# Altium schematic sheet ADC.SchDoc(ADC)
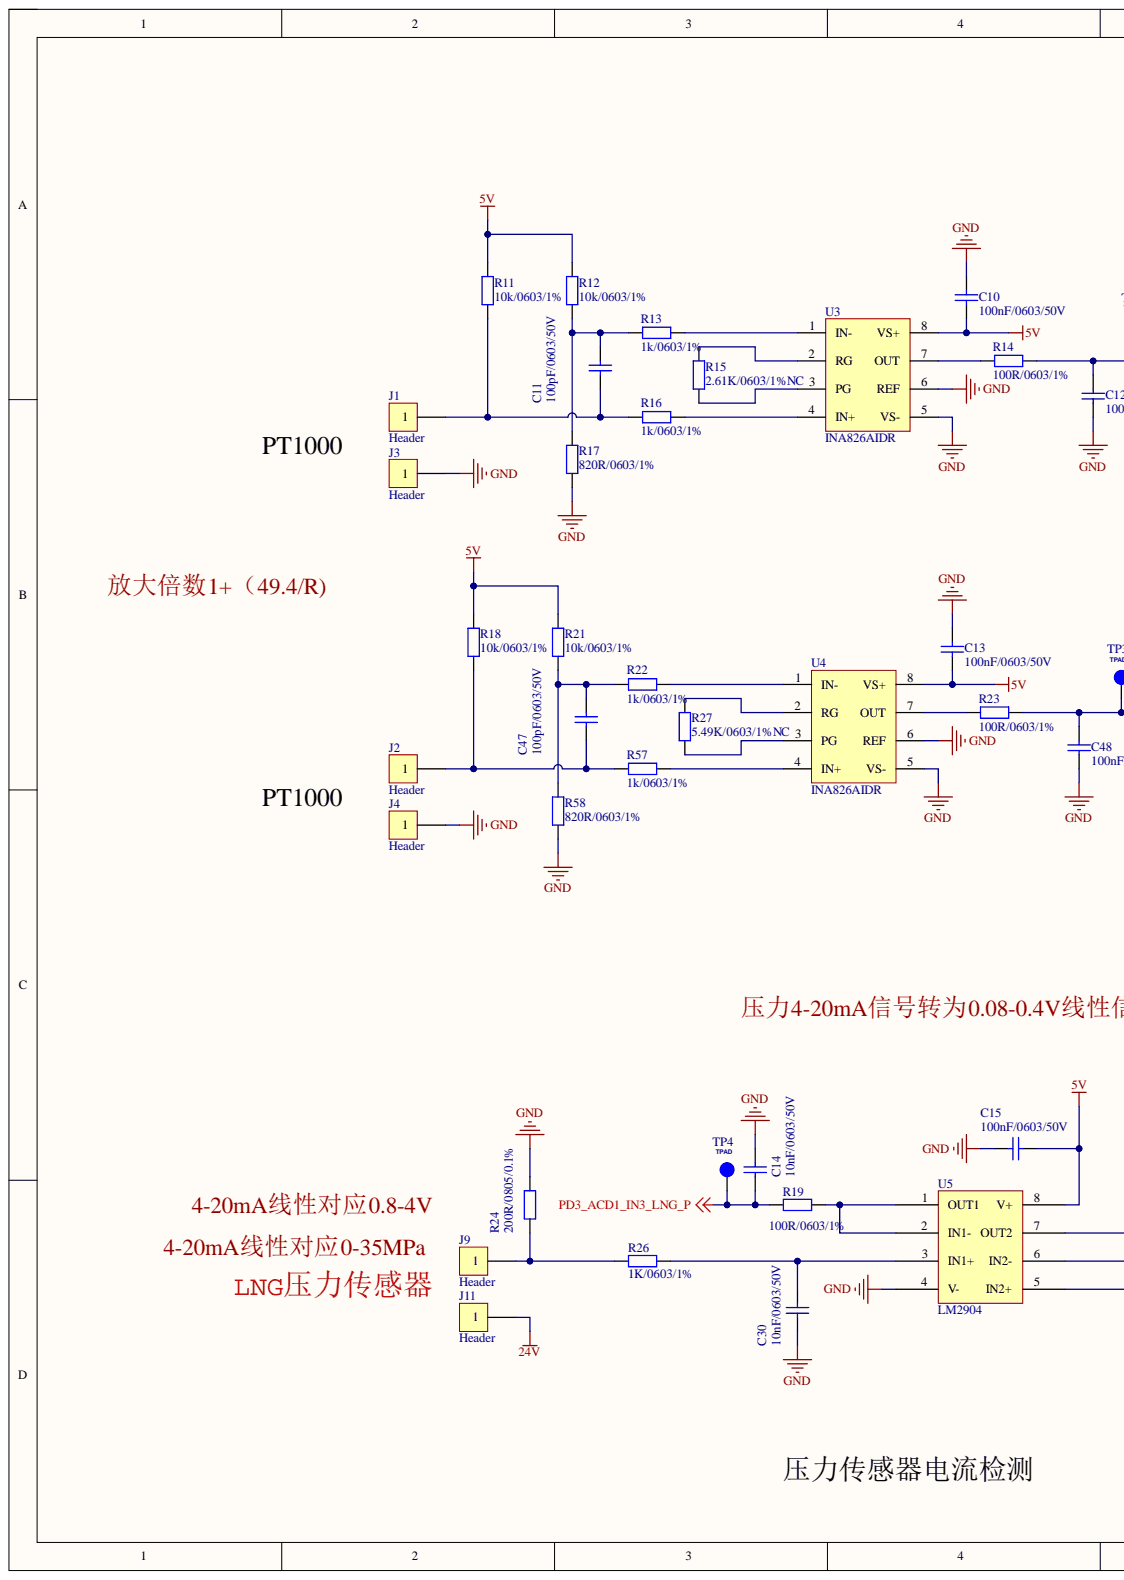
[diagram p0: ADC.SchDoc, left]
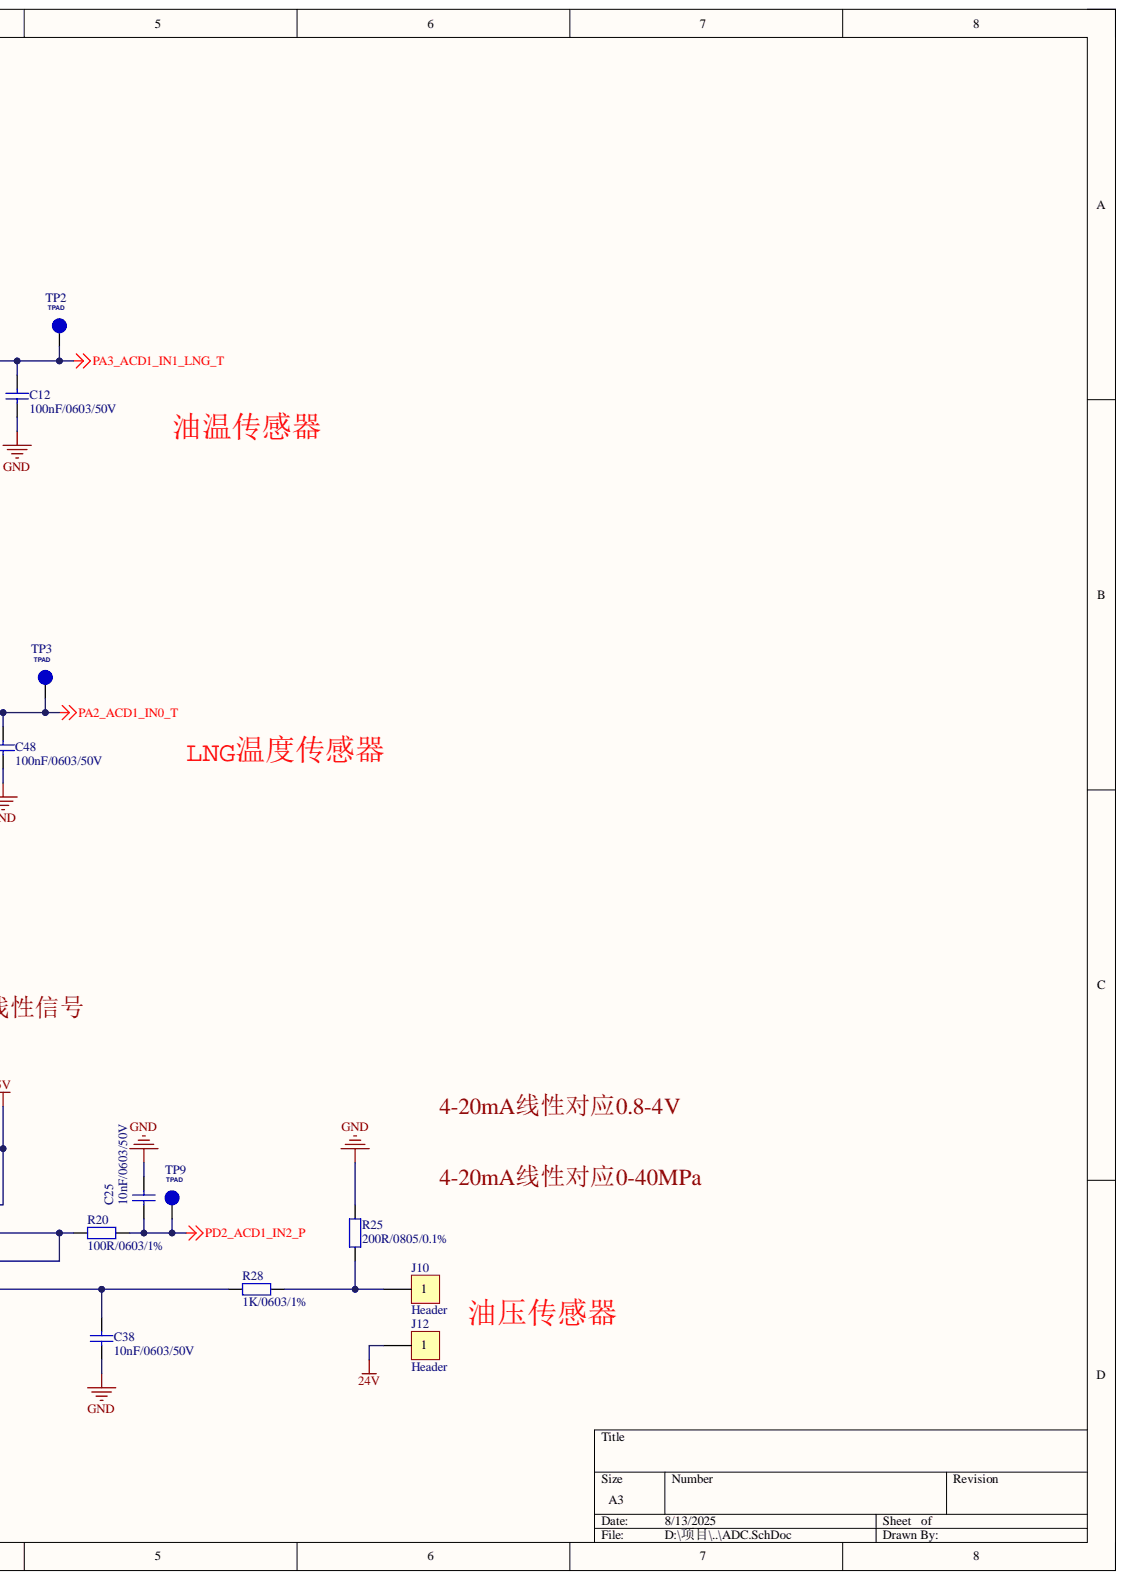
[diagram p1: ADC.SchDoc, right]

## Parts
C10  100nF/0603/50V  [RC0603]
C11  100pF/0603/50V  [RC0603]
C12  100nF/0603/50V  [RC0603]
C13  100nF/0603/50V  [RC0603]
C14  10nF/0603/50V  [RC0603]
C15  100nF/0603/50V  [RC0603]
C25  10nF/0603/50V  [RC0603]
C30  10nF/0603/50V  [RC0603]
C38  10nF/0603/50V  [RC0603]
C47  100pF/0603/50V  [RC0603]
C48  100nF/0603/50V  [RC0603]
J1  Header  [J]
J2  Header  [J]
J3  Header  [J]
J4  Header  [J]
J9  Header  [J]
J10  Header  [J]
J11  Header  [J]
J12  Header  [J]
R11  10k/0603/1%  [RC0603]
R12  10k/0603/1%  [RC0603]
R13  1k/0603/1%  [RC0603]
R14  100R/0603/1%  [RC0603]
R15  2.61K/0603/1%NC  [RC0603]
R16  1k/0603/1%  [RC0603]
R17  820R/0603/1%  [RC0603]
R18  10k/0603/1%  [RC0603]
R19  100R/0603/1%  [RC0603]
R20  100R/0603/1%  [RC0603]
R21  10k/0603/1%  [RC0603]
R22  1k/0603/1%  [RC0603]
R23  100R/0603/1%  [RC0603]
R24  200R/0805/0.1%  [RC0805]
R25  200R/0805/0.1%  [RC0805]
R26  1K/0603/1%  [RC0603]
R27  5.49K/0603/1%NC  [RC0603]
R28  1K/0603/1%  [RC0603]
R57  1k/0603/1%  [RC0603]
R58  820R/0603/1%  [RC0603]
TP2  NOTE: TPAD  [TP]  (hidden comment: TPAD_030)
TP3  NOTE: TPAD  [TP]  (hidden comment: TPAD_030)
TP4  NOTE: TPAD  [TP]  (hidden comment: TPAD_030)
TP9  NOTE: TPAD  [TP]  (hidden comment: TPAD_030)
U3  INA826AIDR  [SOIC-8]
U4  INA826AIDR  [SOIC-8]
U5  LM2904  [SOIC-8]

## Nets
5V: C10.2 C13.2 C15.1 R11.2 R12.2 R18.2 R21.2 U3.8(VS+) U4.8(VS+) U5.8(V+)
24V: J11.1 J12.1
GND: C10.1 C12.1 C13.1 C14.1 C15.2 C25.1 C30.2 C38.2 C48.1 J3.1 J4.1 R17.1 R24.1 R25.1 R58.1 U3.5(VS-) U3.6(REF) U4.5(VS-) U4.6(REF) U5.4(V-)
PA2_ACD1_IN0_T: C48.2 R23.1 TP3.1
PA3_ACD1_IN1_LNG_T: C12.2 R14.1 TP2.1
PD2_ACD1_IN2_P: C25.2 R20.1 TP9.1
PD3_ACD1_IN3_LNG_P: C14.2 R19.1 TP4.1
NetC11_1: C11.1 J1.1 R11.1 R16.2
NetC11_2: C11.2 R12.1 R13.2 R17.2
NetC30_1: C30.1 R26.1 U5.3(IN1+)
NetC38_1: C38.1 R28.1 U5.5(IN2+)
NetC47_1: C47.1 J2.1 R18.1 R57.2
NetC47_2: C47.2 R21.1 R22.2 R58.2
NetJ9_1: J9.1 R24.2 R26.2
NetJ10_1: J10.1 R25.2 R28.2
NetR13_1: R13.1 U3.1(IN-)
NetR14_2: R14.2 U3.7(OUT)
NetR15_1: R15.1 U3.3(PG)
NetR15_2: R15.2 U3.2(RG)
NetR16_1: R16.1 U3.4(IN+)
NetR19_2: R19.2 U5.1(OUT1) U5.2(IN1-)
NetR20_2: R20.2 U5.6(IN2-) U5.7(OUT2)
NetR22_1: R22.1 U4.1(IN-)
NetR23_2: R23.2 U4.7(OUT)
NetR27_1: R27.1 U4.3(PG)
NetR27_2: R27.2 U4.2(RG)
NetR57_1: R57.1 U4.4(IN+)

## Library data of the parts
C10 C12 C13 C48 = C: 贴片电容/MLCC电容; BOM名称: 无极性贴片电容; 联系下单店铺卖家 免费帮你查找封装: 联系下单店铺卖家 免费技术指导; Component Kind: Standard; Library Name: 0.1 - 电阻-电容-电感-保险丝-晶振-晶体-磁珠-电位器-法拉电容-馈通电容.SchLib
C11 C14 C15 C25 C30 C38 C47 = Cap: Capacitor; Value: 100pF; Component Kind: Standard; LatestRevisionDate: 17-Jul-2002; LatestRevisionNote: Re-released for DXP Platform.; Library Name: Miscellaneous Devices.IntLib; PackageDescription: Radial Cap, Thru-Hole; 2 Leads; 0.3 in Pin Spacing; PackageReference: RAD-0.3; Published: 8-Jun-2000; Signal Integrity: Cap; Simulation: CAP; Publisher: Altium Limited
R11-R18 R21-R25 R27 R57 R58 = R: 贴片电阻; 联系下单店铺卖家 免费帮你查找封装: 联系下单店铺卖家 免费技术指导; BOM名称: 贴片电阻; Component Kind: Standard; Library Name: 0.1 - 电阻-电容-电感-保险丝-晶振-晶体-磁珠-电位器-法拉电容-馈通电容.SchLib
R19 R20 R26 R28 = Res2: Resistor; Value: 1K; Component Kind: Standard; LatestRevisionDate: 17-Jul-2002; LatestRevisionNote: Re-released for DXP Platform.; Library Name: Miscellaneous Devices.IntLib; PackageDescription: Axial Device, Thru-Hole; 2 Leads; 0.4 in Pin Spacing; PackageReference: AXIAL-0.4; Published: 8-Jun-2000; Signal Integrity: Res; Simulation: RESISTOR; Publisher: Altium Limited
TP2 TP3 = TESTLOOP: TEST POINT PAD 30MIL DIA SMT, NO PART TO ORDER; AGILE_PN: 211-76642; CAD_APPROVED: Yes; CLASS: IO; MFG_NAME01: NOTACOMPONENT; PCB Footprint: test_smd_1_2mm; ROOM: POWER SUPPLY_LR; 3D_Mechanical_Footprint_File: http://fcl.am.freescale.net/ALLEGRO/step/tpad_030.STEP; Component Kind: Standard; HEIGHT: 0.1MM; MFG_PN01: NOTACOMPONENT; ROHS: Yes
TP4 TP9 = TESTLOOP: TP; AGILE_PN: 211-76642; CAD_APPROVED: Yes; CLASS: IO; MFG_NAME01: NOTACOMPONENT; PCB Footprint: test_smd_1_2mm; ROOM: POWER SUPPLY_LR; 3D_Mechanical_Footprint_File: http://fcl.am.freescale.net/ALLEGRO/step/tpad_030.STEP; Component Kind: Standard; HEIGHT: 0.1MM; MFG_PN01: NOTACOMPONENT; ROHS: Yes
U3 U4 = INA826: Component Kind: Standard; Library Name: Schlib1.SchLib
J1-J4 J9-J12 = Header: Component Kind: Standard; Library Name: ZZL_ZY_AC7840.SchLib
U5 = LM2904: Component Kind: Standard; Library Name: ZZ_DCMV4_Library.SchLib
